# Altium schematic sheet STN1170_RN-42 Toplevel Rev2.SchDoc
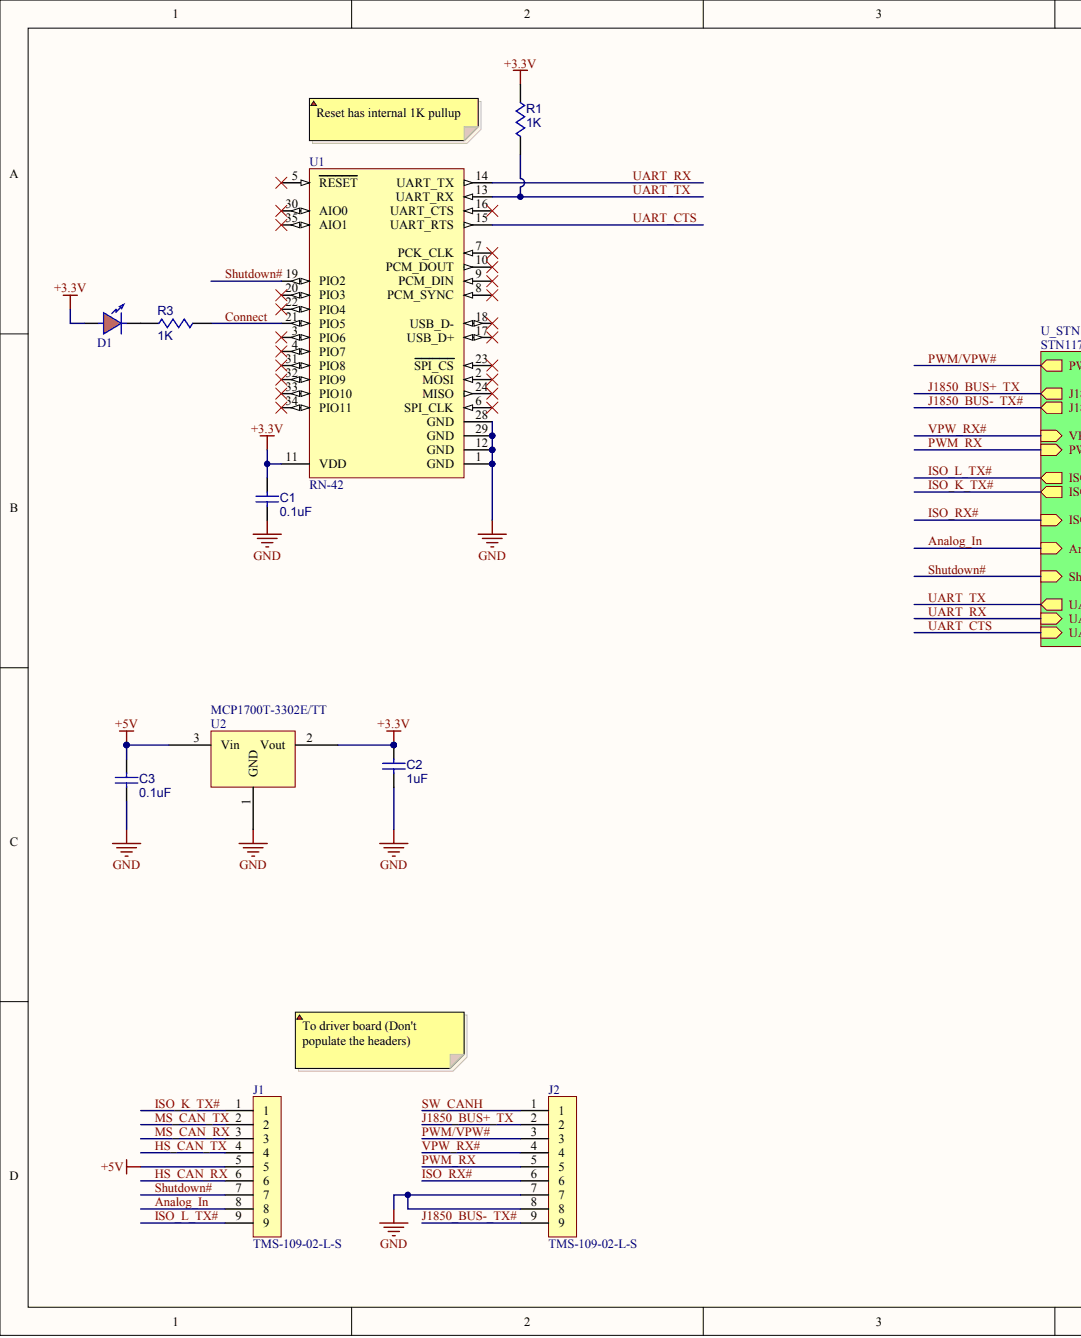
[diagram p0: STN1170_RN-42 Toplevel Rev2.SchDoc, left]
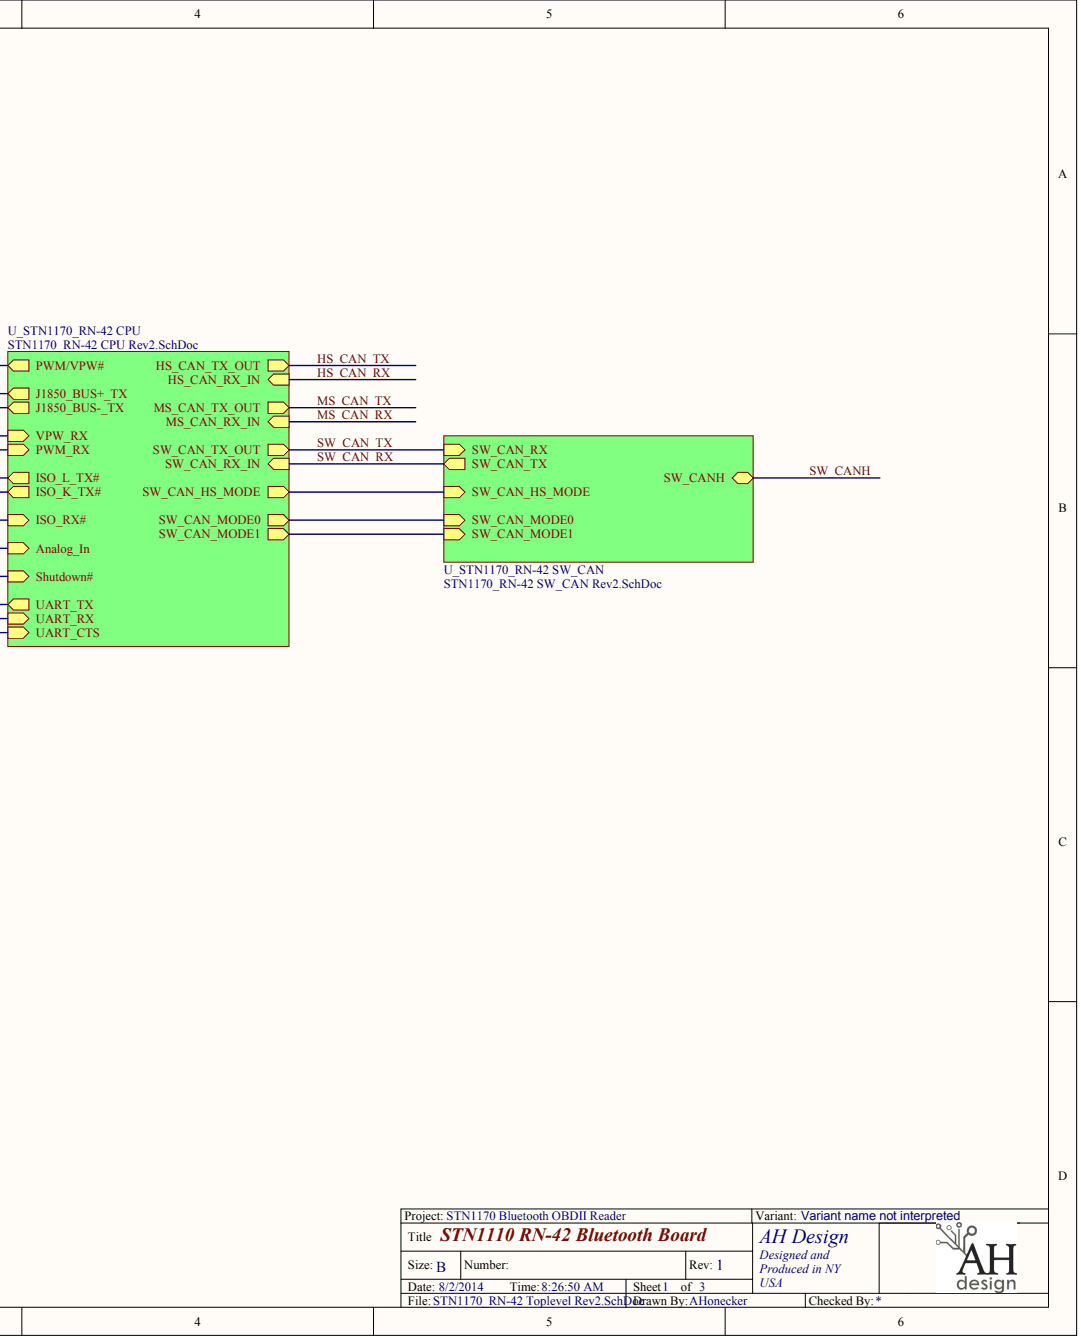
[diagram p1: STN1170_RN-42 Toplevel Rev2.SchDoc, right]

## Parts
C1  0.1uF
C2  1uF
C3  0.1uF
D1  APA1606SECK  (value not drawn)
J1  TMS-109-02-L-S
J2  TMS-109-02-L-S
R1  1K
R3  1K
U1  RN-42
U2  MCP1700T-3302E/TT

## Nets
+3.3V: C1.1 C2.2 D1.A R1.2 U1.11(VDD) U2.2
+5V: C3.1 J1.5 U2.3
GND: C1.2 C2.1 C3.2 J2.7 J2.8 U1.1(GND) U1.12(GND) U1.28(GND) U1.29(GND) U2.1
ANALOG_IN: J1.8
CONNECT: R3.2 U1.21(PIO5)
HS_CAN_RX: J1.6
HS_CAN_TX: J1.4
ISO_K_TX#: J1.1
ISO_L_TX#: J1.9
ISO_RX#: J2.6
J1850_BUS+_TX: J2.2
J1850_BUS-_TX#: J2.9
MS_CAN_RX: J1.3
MS_CAN_TX: J1.2
PWM/VPW#: J2.3
PWM_RX: J2.5
SHUTDOWN#: J1.7 U1.19(PIO2)
SW_CANH: J2.1
UART_CTS: U1.15(UART_RTS)
UART_RX: U1.14(UART_TX)
UART_TX: R1.1 U1.13(UART_RX)
VPW_RX#: J2.4
NetD1_C: D1.C R3.1
NetU1_2: U1.2(MOSI)
NetU1_3: U1.3(PIO6)
NetU1_4: U1.4(PIO7)
NetU1_5: U1.5(RESET)
NetU1_6: U1.6(SPI_CLK)
NetU1_7: U1.7(PCK_CLK)
NetU1_8: U1.8(PCM_SYNC)
NetU1_9: U1.9(PCM_DIN)
NetU1_10: U1.10(PCM_DOUT)
NetU1_16: U1.16(UART_CTS)
NetU1_17: U1.17(USB_D+)
NetU1_18: U1.18(USB_D-)
NetU1_20: U1.20(PIO3)
NetU1_22: U1.22(PIO4)
NetU1_23: U1.23(SPI_CS)
NetU1_24: U1.24(MISO)
NetU1_30: U1.30(AIO0)
NetU1_31: U1.31(PIO8)
NetU1_32: U1.32(PIO9)
NetU1_33: U1.33(PIO10)
NetU1_34: U1.34(PIO11)
NetU1_35: U1.35(AIO1)
SW_CAN_HS_MODE:
SW_CAN_MODE0:
SW_CAN_MODE1:
SW_CAN_RX:
SW_CAN_TX:

## Library data of the parts
C1 C3 = (no symbol name): Manufacturer: Kemet; PartNumber: C0603C104M5RAC; Voltage: 50; ItemID: 0.1uF,50V,CC0603,X7R,+/-20%; Material: X7R; Tolerance: +/-20%; Component Subkind: Capacitor; Approved: Pending; Value: 0.1uF; LeadFree: Yes; RoHS: Yes; Published: 7/18/2007; Component Kind: Standard; Component Type: Standard; Designator: C?; Manufacturer2: CAL-CHIP; Manufacturer3: -; Manufacturer4: -; Pin Count: 2; PartNumber2: GMC10X7R10450NT-LF; ComponentLink1URL: \PassivesDatasheets\F3102_CE.pdf; ComponentLink2URL: \PassivesDatasheets\gmc_series.pdf; ComponentLink3URL: -; ComponentLink4URL: -; ComponentLink1Description: Datasheet; ComponentLink2Description: Datasheet; ComponentLink3Description: Datasheet; ComponentLink4Description: Datasheet; Publisher: AltiumdBManager
C2 = (no symbol name): Manufacturer: Kemet Electronics Corp; PartNumber: C0603C105M9RAC; PartStatus1: Active; Voltage: 6.3; ItemID: 1uF,6.3V,CC0603,X7R,+/-20%; Material: X7R; Tolerance: +/-20%; Component Subkind: Capacitor; Approved: Yes; ItemWeight1_mg: 240.300003051758; ItemWeight2_mg: 0; Approver: IHS; Value: 1uF; LeadFree: Yes; RoHS: Yes; Published: 1/5/2011 12:07:09 PM; Component Kind: Standard; Component Type: Standard; Designator: C?; Manufacturer2: Cal-Chip Electronics; Manufacturer3: -; Manufacturer4: -; Pin Count: 2; PartNumber3: -; PartNumber4: -; Status: Active; ComponentLink1URL: \PassivesDatasheets\F3102_CE.pdf; ComponentLink2URL: \PassivesDatasheets\gmc_series.pdf; ComponentLink3URL: -; ComponentLink4URL: -; ComponentLink1Description: Datasheet; ComponentLink2Description: Datasheet; ComponentLink3Description: Datasheet; ComponentLink4Description: Datasheet; PartStatus3: -; PartStatus4: -; ItemWeight3_mg: 0; ItemWeight4_mg: 0; Publisher: AltiumDBManager1.4-MWyman
D1 = (no symbol name): Manufacturer: Kingbright Corp.; Approved: No; TargetLibrary: LEDs; Value: 2.1V; ComponentLink1Description: Datasheet; ComponentLink1URL: http://www.us.kingbright.com/images/catalog/SPEC/APA1606SECK.pdf; LeadFree: Yes; PartNumber: APA1606SECK; Published: 1/18/2010; RoHS: Yes; Status: Active; Publisher: Vanteon - AHonecker
J1 J2 = (no symbol name): Manufacturer: Samtec; PartNumber: TMS-109-02-L-S
R1 R3 = (no symbol name): Manufacturer: Panasonic; PartNumber: ERJ3GEYJ102V; Tolerance: 5%; Watts: 0.1W; ItemID: 1K,R0603,5%; Component SubKind: Resistor; Voltage: 75; MetricFootprint: R1608MM; Value: 1K; Manufacturer2: Vishay/Dale; Manufacturer3: KOA/Speer; Manufacturer4: ROHM; PartNumber2: CRCW06031KJKED; PartNumber3: RK73B1JTTD102J; PartNumber4: MCR03EZPJ102; Material: Thick-Film; LeadFree: Yes; RoHS: Yes; Published: 7/16/2009; Component Kind: Standard; Component Type: Standard; Pin Count: 2; Spice Prefix: R; ComponentLink1URL: http://industrial.panasonic.com/www-data/pdf/AOA0000/AOA0000CE1.pdf; ComponentLink2URL: \PassivesDatasheets\Vishay_dcrcw.pdf; ComponentLink3URL: \PassivesDatasheets\KOA_Speer_2%_5%.pdf; ComponentLink4URL: \PassivesDatasheets\mcr03.pdf; ComponentLink1Description: Datasheet; ComponentLink2Description: Datasheet; ComponentLink3Description: Datasheet; ComponentLink4Description: Datasheet; Publisher: AltiumDBManager1.1
U1 = (no symbol name): Manufacturer: Roving Networks; PartNumber: RN-42
U2 = (no symbol name): Manufacturer: Microchip Technology; PartNumber: MCP1700T-3302E/TT
